# Altium schematic sheet Power_Supply.SchDoc(Power_Supply)
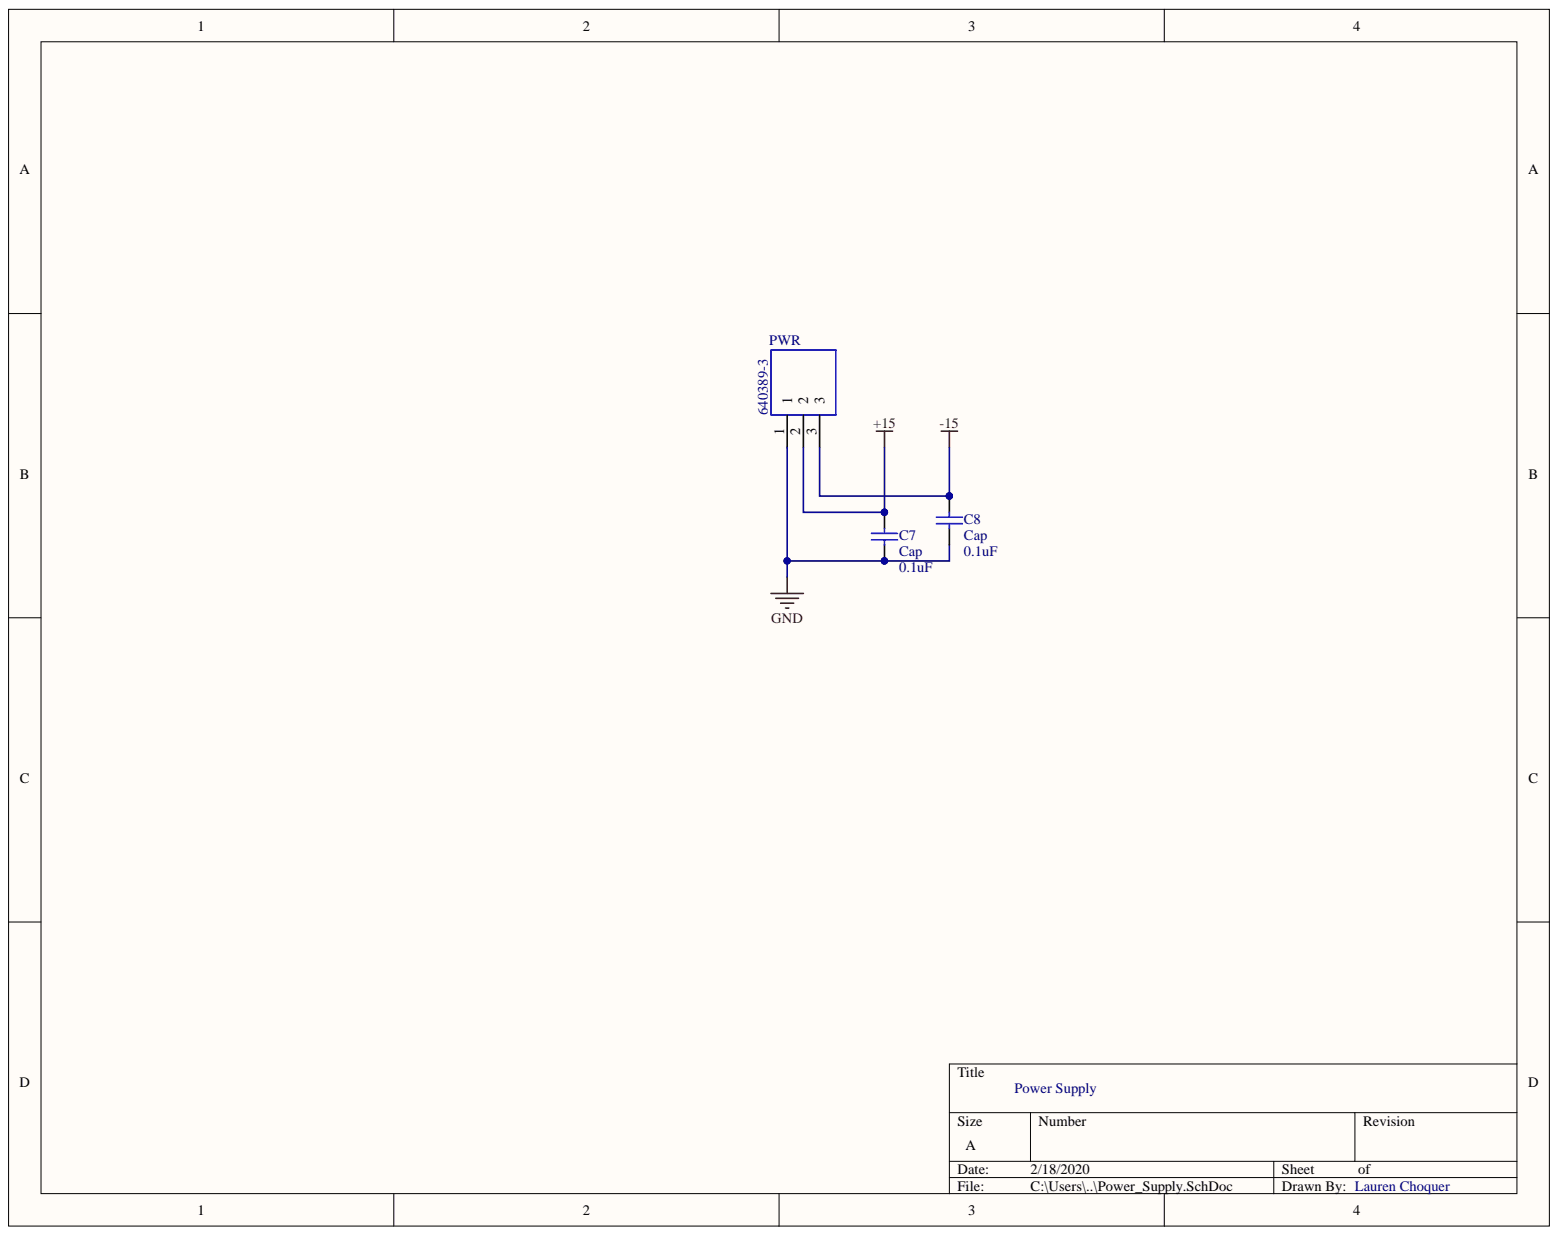
[diagram p0: Power_Supply.SchDoc]

## Parts
C7  Cap  value 0.1uF  [RAD-0.3]
C8  Cap  value 0.1uF  [RAD-0.3]
PWR  640389-3  [640389-3]

## Nets
+15: C7.2 PWR.2
-15: C8.2 PWR.3
GND: C7.1 C8.1 PWR.1

## Library data of the parts
C7 C8 = Cap: Capacitor; Simulation: CAP; Signal Integrity: Cap; Published: 8-Jun-2000; PackageReference: RAD-0.3; PackageDescription: Radial Cap, Thru-Hole; 2 Leads; 0.3 in Pin Spacing; Library Name: Miscellaneous Devices.IntLib; LatestRevisionNote: Re-released for DXP Platform.; LatestRevisionDate: 17-Jul-2002; Component Kind: Standard; Publisher: Altium Limited
PWR = 640389-3: 3 way r/a friction lock header,3.96mm TE Connectivity MTA-156 Series, 3.96mm Pitch 3 Way 1 Row Right Angle PCB Header, Solder Termination, 7A; Allied_Number: 70083704; RS Price/Stock: http://uk.rs-online.com/web/p/products/5355894P; RS Part Number: 5355894P; Mouser Price/Stock: https://www.mouser.com/Search/Refine.aspx?Keyword=571-6403893; Mouser Part Number: 571-6403893; Manufacturer_Part_Number: 640389-3; Manufacturer_Name: TE Connectivity; Library Name: 640389-3.SchLib; Datasheet Link: http://docs-emea.rs-online.com/webdocs/06b6/0900766b806b63a3.pdf; Component Kind: Standard; Allied Price/Stock: http://www.alliedelec.com/te-connectivity-640389-3/70083704/
